# Altium schematic sheet Grace.SchDoc(Grace)
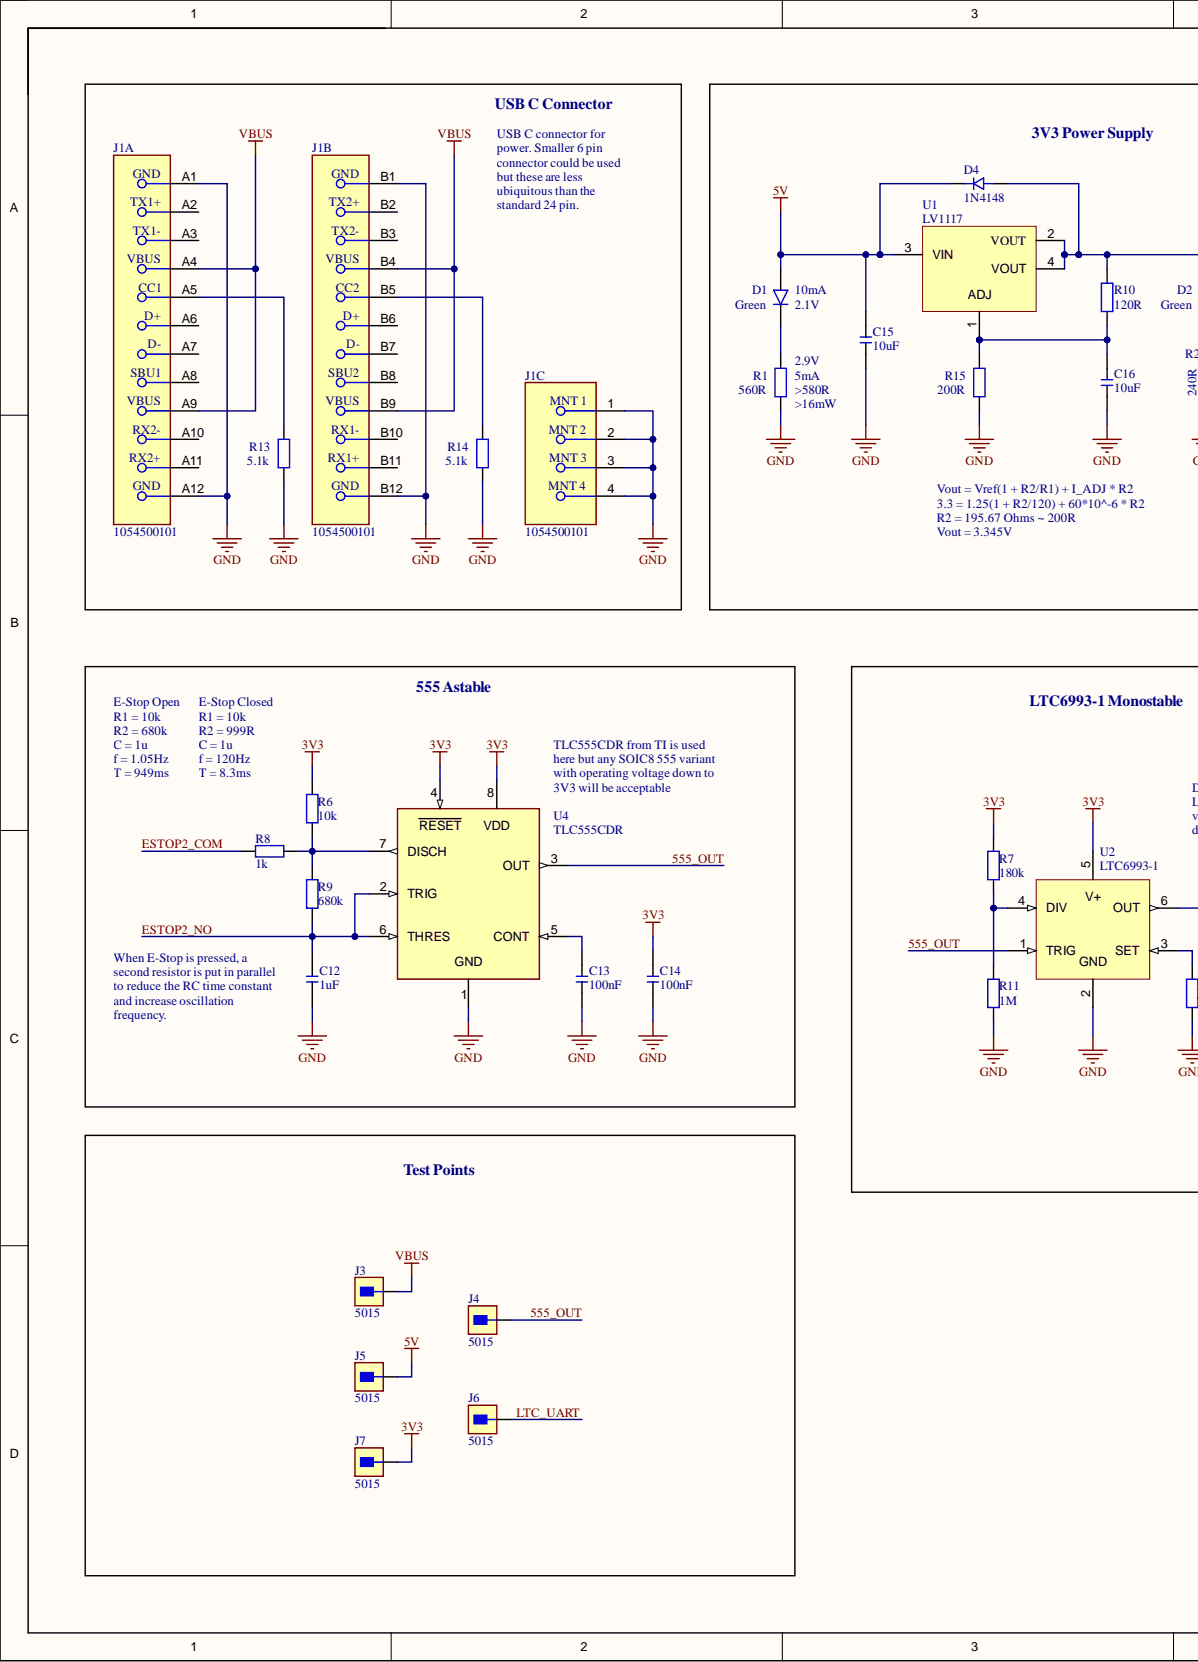
[diagram p0: Grace.SchDoc, left]
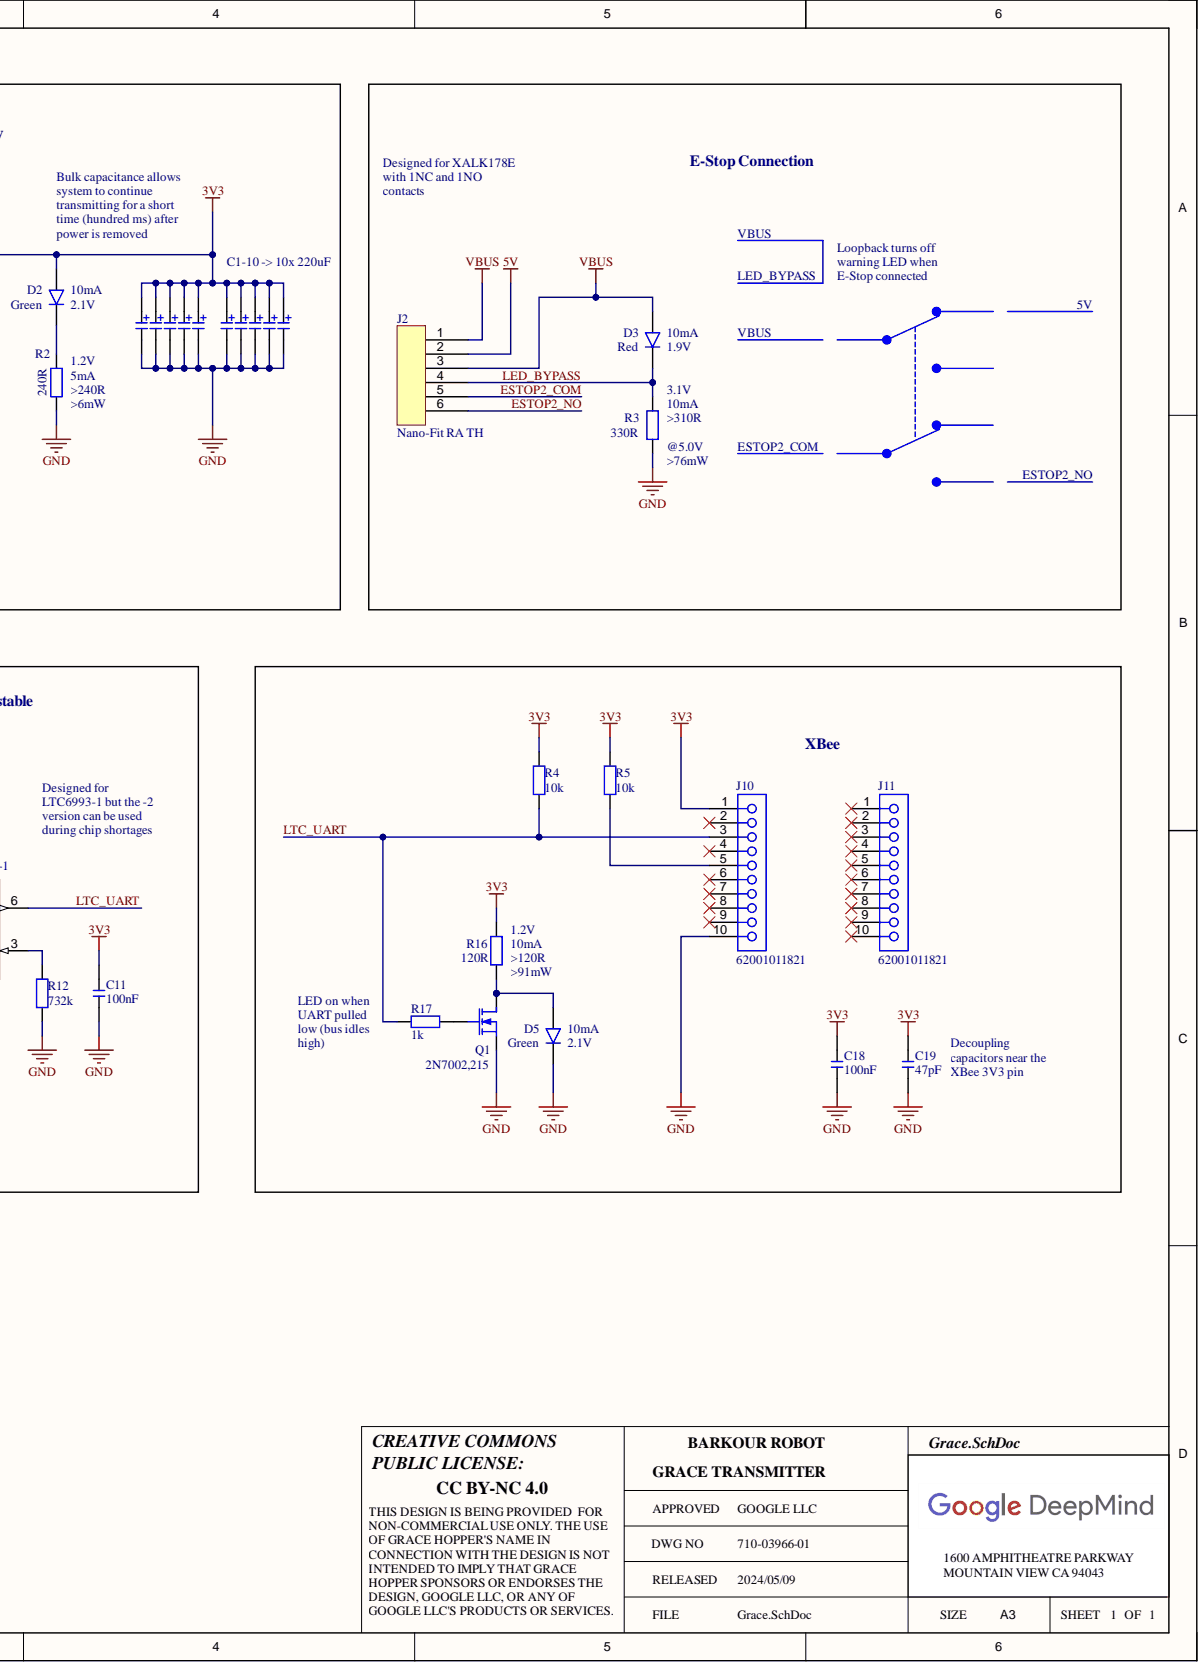
[diagram p1: Grace.SchDoc, right]

## Parts
C1  220uF  [CAP ALSMD 6.6MM H7.7MM]
C2  220uF  [CAP ALSMD 6.6MM H7.7MM]  (value not drawn)
C3  220uF  [CAP ALSMD 6.6MM H7.7MM]  (value not drawn)
C4  220uF  [CAP ALSMD 6.6MM H7.7MM]  (value not drawn)
C5  220uF  [CAP ALSMD 6.6MM H7.7MM]  (value not drawn)
C6  220uF  [CAP ALSMD 6.6MM H7.7MM]  (value not drawn)
C7  220uF  [CAP ALSMD 6.6MM H7.7MM]
C8  220uF  [CAP ALSMD 6.6MM H7.7MM]  (value not drawn)
C9  220uF  [CAP ALSMD 6.6MM H7.7MM]
C10  220uF  [CAP ALSMD 6.6MM H7.7MM]
C11  100nF  [CAP 0603_1608 RF]
C12  1uF  [CAP 0603_1608 RF]
C13  100nF  [CAP 0603_1608 RF]
C14  100nF  [CAP 0603_1608 RF]
C15  10uF  [CAP 1206_3216]
C16  10uF  [CAP 1206_3216]
C18  100nF  [CAP 0603_1608 RF]
C19  47pF  [CAP 0603_1608 RF]
D1  Colour: Green  Forward Current: 10mA  Forward Voltage: 2.1V  [LED TH 5mm]  (hidden comment: HLMP-3507)
D2  Colour: Green  Forward Current: 10mA  Forward Voltage: 2.1V  [LED TH 5mm]  (hidden comment: HLMP-3507)
D3  Colour: Red  Forward Current: 10mA  Forward Voltage: 1.9V  [LED TH 5mm]  (hidden comment: HLMP-3301)
D4  1N4148WS-7-F  [SOD323]  (value not drawn)
D5  Colour: Green  Forward Current: 10mA  Forward Voltage: 2.1V  [LED TH 5mm]  (hidden comment: HLMP-3507)
J1  1054500101  [Molex 1054500101 - With Model]  (parts A,B,C)
J2  Colloquial: Nano-Fit RA TH  [MOLEX 1053131106]  (hidden comment: 105313-1206)
J3  5015  [FP-5015-MFG-RF]
J4  5015  [FP-5015-MFG-RF]
J5  5015  [FP-5015-MFG-RF]
J6  5015  [FP-5015-MFG-RF]
J7  5015  [FP-5015-MFG-RF]
J10  62001011821  [62001011821]
J11  62001011821  [62001011821]
Q1  2N7002,215  [NEXPERIA SOT-23-3]
R1  560R  [RES 0603_1608 RF]
R2  240R  [RES 0603_1608 RF]
R3  330R  [RES 0603_1608 RF]
R4  10k  [RES 0603_1608 RF]
R5  10k  [RES 0603_1608 RF]
R6  10k  [RES 0603_1608 RF]
R7  180k  [RES 0603_1608 RF]
R8  1k  [RES 0603_1608 RF]
R9  680k  [RES 0603_1608 RF]
R10  120R  [RES 1206_3216 RF]
R11  1M  [RES 0603_1608 RF]
R12  732k  [RES 0603_1608 RF]
R13  5.1k  [RES 0603_1608 RF]
R14  5.1k  [RES 0603_1608 RF]
R15  200R  [RES 1206_3216 RF]
R16  120R  [RES 1206_3216 RF]
R17  1k  [RES 0603_1608 RF]
U1  Colloquial: LV1117  [TI SOT-223 DCY]  (hidden comment: TLV1117CDCY)
U2  LTC6993CS6-1#TRMPBF  [LT TSOT-23-6 S6]  (value not drawn)
U4  TLC555CDR  [TI SOIC-8 D0008A]

## Nets
3V3: C1.1 C2.1 C3.1 C4.1 C5.1 C6.1 C7.1 C8.1 C9.1 C10.1 C11.2 C14.2 C18.2 C19.2 D2.2 D4.1 J7.1 J10.1 R4.2 R5.2 R6.2 R7.2 R10.2 R16.2 U1.2(VOUT) U1.4(VOUT) U2.5(V+) U4.4 U4.8
5V: C15.2 D1.2 D4.2 J2.2 J5.1 U1.3(VIN)
GND: C1.2 C2.2 C3.2 C4.2 C5.2 C6.2 C7.2 C8.2 C9.2 C10.2 C11.1 C12.1 C13.1 C14.1 C15.1 C16.1 C18.1 C19.1 D5.1 J1.1 J1.2 J1.3 J1.4 J1.A1 J1.A12 J1.B1 J1.B12 J10.10 Q1.2 R1.1 R2.1 R3.1 R11.1 R12.1 R13.1 R14.1 R15.1 U2.2 U4.1
VBUS: D3.2 J1.A4 J1.A9 J1.B4 J1.B9 J2.1 J2.3 J3.1
555_OUT: J4.1 U2.1(TRIG) U4.3(OUT)
ESTOP2_COM: J2.5 R8.1
ESTOP2_NO: C12.2 J2.6 R9.1 U4.2(TRIG) U4.6(THRES)
LED_BYPASS: D3.1 J2.4 R3.2
LTC_UART: J6.1 J10.3 R4.1 R17.1 U2.6(OUT)
NetC13_2: C13.2 U4.5(CONT)
NetC16_2: C16.2 R10.1 R15.2 U1.1
NetD1_1: D1.1 R1.2
NetD2_1: D2.1 R2.2
NetD5_2: D5.2 Q1.3 R16.1
NetJ1_A5: J1.A5 R13.2
NetJ1_B5: J1.B5 R14.2
NetJ10_5: J10.5 R5.1
NetQ1_1: Q1.1 R17.2
NetR6_1: R6.1 R8.2 R9.2 U4.7(DISCH)
NetR7_1: R7.1 R11.2 U2.4(DIV)
NetR12_2: R12.2 U2.3(SET)

Not connected: J1 A2 A3 A6 A7 A8 A10 A11 B2 B3 B6 B7 B8 B10 B11; J10 2 4 6 7 8 9; J11 1 2 3 4 5 6 7 8 9 10

## Library data of the parts
C1-C10 = C_POL: Polarised Capacitor; Tolerance: 20%; Voltage Rating: 10V; Capacitance: 220uF; Component Kind: Standard; Diameter: 6.3mm; Height: 7.7mm; Library Name: DMR_common_lib.SchLib
C11 C13 C14 C18 = C: Capacitor; Dielectric: X7R; Tolerance: 20%; Voltage Rating: 25V; Capacitance: 100nF; Component Kind: Standard; Size Code: 0603; Library Name: DMR_common_lib.SchLib
C12 = C: Capacitor; Dielectric: X7R; Tolerance: 20%; Voltage Rating: 10V; Capacitance: 1uF; Component Kind: Standard; Size Code: 0603; Library Name: DMR_common_lib.SchLib
C15 C16 = C: Generic Capacitor; Temperature Coefficient: X5R; Tolerance: 10%; Voltage Rating: 25V; Capacitance: 10uF; Component Kind: Standard; Size Code: 1206; Library Name: DMR_common_lib.SchLib
C19 = C: Capacitor; Dielectric: C0G; Tolerance: 20%; Voltage Rating: 50V; Capacitance: 47pF; Component Kind: Standard; Size Code: 0603; Library Name: DMR_common_lib.SchLib
D1 D2 D5 = LED_TH5_GREEN: Green 5mm TH LED, T-1 3/4, 10mA Ic, 2.1V; Luminous Intensity: 4.7mcd; Wavelength: 569nm; Case Size: 5mm; Component Kind: Standard; Manufacturer Part Number: HLMP-3507; Library Name: DMR_common_lib.SchLib
D3 = LED_TH5_RED: Red 5mm TH LED, T-1 3/4, 10mA Ic, 1.9V; Luminous Intensity: 6.1mcd; Wavelength: 626nm; Case Size: 5mm; Component Kind: Standard; Manufacturer Part Number: HLMP-3301; Library Name: DMR_common_lib.SchLib
D4 = 1N4148: Signal Diode VR=75V IF=150mA Trr=4ns P=200mW; Manufacturer: Diodes; Average Rectified Current: 150mA; Capacitance: 2pF; Element Configuration: Single; Forward Current: 300mA; Forward Voltage: 1.25V; Max Forward Surge Current (Ifsm): 2A; Max Repetitive Reverse Voltage (Vrrm): 75V; Max Reverse Current: 1uA; Polarity: Standard; Power Dissipation: 200mW; Recovery Time: 4ns; Category: Rectifier Diodes; Colloquial: 1N4148; Component Kind: Standard; Contact Plating: Tin,Matte; Current Rating: 150mA; Height: 1mm; Lead Free: Lead Free; Length: 1.8mm; Manufacturer Part Number: 1N4148WS-7-F; Max Operating Temperature: 150°C; Max Reverse Voltage (DC): 75V; Max Surge Current: 2A; Min Operating Temperature: -65°C; Mount: Surface Mount; Number of Pins: 2; Output Current: 150mA; Package Quantity: 3000; Packaging: Tape and Reel; Peak Non-Repetitive Surge Current: 2A; Power Rating: 200mW; Pricing 1: 3000=0.03600, 6000=0.03200, 12000=0.02900, 30000=0.02700, 60000=0.02300 (USD); Radiation Hardening: No; REACH SVHC: No SVHC; Reverse Recovery Time: 4ns; Reverse Recovery Time-Max: 4ns; RoHS: Yes; RoHS Compliant: Yes; Series: -; Stock 1: 18000; Supplier 1: RSComponents; Supplier Part Number 1: 9228070; Voltage: 75V; Voltage Rating (DC): 75V; Weight: 0.000173oz; Width: 1.4mm; Library Name: DMR_common_lib.SchLib
J1 = 1054500101: Conn USB 3.1 Type C F 24 POS Solder RA SMD 24 Terminal 1 Port USB 3.1 T/R; Manufacturer: Molex; Conn Orientation: Right Angle; Current Rating: 0.25/1.25/5A; Is Female: yes; Number Of Contacts: 24; Pitch: 0.5mm; Shielding: true; Usb Standard: USB 3.1; Voltage Rating: 30V; Automotive: No; Category: Conn; Component Kind: Standard; ComponentLink2Description: Datasheet; ComponentLink2URL: https://www.molex.com/webdocs/datasheets/pdf/en-us/1054500101_IO_CONNECTORS.pdf; Contact Material: Gold,Nickel; Device Class L1: Connectors; Device Class L2: USB Connectors; Device Class L3: unset; Digikey Description: CONN RCP USB3.1 TYPEC 24P SMD RA; Footprint Url: https://www.molex.com/pdm_docs/sd/1054500101_sd.pdf; Height: 3.44mm; Lead Free: Yes; Manufacturer Part Number: 1054500101; Mouser Description: USB Shielded I/O Receptacle; Type C; Right Angle; Surface Mount; Gold over Nickel; Octopart: https://octopart.com/105450-0101-molex-68082325; Package: 0; RoHS: TRUE; Temperature: -30°C to +85°C; Temperature Range High: +85°C; Temperature Range Low: -30°C; Library Name: DMR_common_lib.SchLib
J2 = Nano-Fit RA TH 6 Way Header: Nano-Fit Right Angle Header; Through Hole; 2.50mm; Single Row; 6 Circuit; Gold Plated; Manufacturer: Molex; Gender: Male; Category: Headers and Wire Housings; Color: Black; Component Kind: Standard; Contact Material: Brass; Contact Plating: Gold; Manufacturer Part Number: 105313-1206; Mount: Through Hole; Number of Rows: 1; Pricing 1: 10=1.38000 (GBP); REACH SVHC: Unknown; RoHS: Yes; RoHS Compliant: Yes; Stock 1: 621; Supplier 1: RSComponents; Supplier Part Number 1: 1225087; Termination: Through Hole; Library Name: DMR_common_lib.SchLib
J3-J7 = 5015: PC TEST POINT MINIATURE; Manufacturer: keystone; Conn Orientation: Straight; Number Of Contacts: 1; Automotive: No; Category: Conn; Component Kind: Standard; ComponentLink2Description: Datasheet; ComponentLink2URL: http://www.keyelco.com/product-pdf.cfm?p=1353; Contact Material: Silver; Device Class L1: Connectors; Device Class L2: unset; Device Class L3: unset; Digikey Description: PC TEST POINT MINIATURE; Height: 1.65mm; Lead Free: Yes; Manufacturer Part Number: 5015; Octopart: https://octopart.com/5015-keystone-3822; Package: 5015; RoHS: TRUE; Library Name: DMR_common_lib.SchLib
J10 J11 = CMP-1502-01210-2: NexarPartId: 65162168; Contact Resistance: 20mΩ; Current Rating: 2A; Gender: Female; Operating Supply Voltage: 250V; Component Kind: Standard; Lead Pitch: 2mm; Library Name: Altium Content Vault; Number of Pins: 10; Orientation: Vertical; RoHS: Non-Compliant
Q1 = 2N7002: MOSFET N-CH 60V 300MA SOT-23; Manufacturer: Nexperia; Category: MOSFETs; Component Kind: Standard; ComponentLink1Description: http://www.farnell.com/datasheets/1499179.pdf?src-supplier=Farnell; ComponentLink1URL: http://www.farnell.com/datasheets/1499179.pdf?src-supplier=Farnell; ComponentLink2Description: https://assets.nexperia.com/documents/data-sheet/BSS138PS.pdf?src-supplier=Digi-Key; ComponentLink2URL: https://assets.nexperia.com/documents/data-sheet/BSS138PS.pdf?src-supplier=Digi-Key; ComponentLink3Description: https://assets.nexperia.com/documents/data-sheet/2N7002.pdf?src-supplier=Digi-Key; ComponentLink3URL: https://assets.nexperia.com/documents/data-sheet/2N7002.pdf?src-supplier=Digi-Key; ComponentLink4Description: http://www.farnell.com/datasheets/1499179.pdf?src-supplier=Newark; ComponentLink4URL: http://www.farnell.com/datasheets/1499179.pdf?src-supplier=Newark; Manufacturer Part Number: 2N7002,215; Pricing 1: 3000=0.05700, 9000=0.05640, 24000=0.05590, 45000=0.05530 (USD); RoHS: Yes; RoHS Compliant: Yes; Stock 1: 243000; Supplier 1: Arrow Electronics; Supplier Part Number 1: 2N7002,215; Library Name: DMR_common_lib.SchLib
R1-R9 R11-R14 R17 = R: Resistor; Power Rating: 100mW; Temperature Coefficient: 100ppm/°C; Tolerance: 1%; Voltage Rating: 75V; Component Kind: Standard; Size Code: 0603; Library Name: DMR_common_lib.SchLib
  R1: Resistance: 560R
  R2: Resistance: 240R
  R3: Resistance: 330R
  R4-R6: Resistance: 10k
  R7: Resistance: 180k
  R8 R17: Resistance: 1k
  R9: Resistance: 680k
  R11: Resistance: 1M
  R12: Resistance: 732k
  R13 R14: Resistance: 5.1k
R10 R15 R16 = R: Resistor; Power Rating: 250mW; Temperature Coefficient: 100ppm/°C; Tolerance: 1%; Voltage Rating: 200V; Component Kind: Standard; Size Code: 1206; Library Name: DMR_common_lib.SchLib
  R10 R16: Resistance: 120R
  R15: Resistance: 200R
U1 = TLV1117CDCY: LV1117 type LDO Regulator Pos 1.25V to 13.7V 0.8A 4-Pin(3+Tab) SOT-223 Tube; Manufacturer: Texas Instruments; Dropout Voltage: 1.2V; Input Bias Current: 5mA; Max Input Voltage: 15V; Max Output Current: 800mA; Min Input Voltage: 2.7V; Min Output Voltage: 1.238V; Number of Outputs: 1; Output Type: Adjustable; Output Voltage: 13.75V; Polarity: Positive; Reference Voltage: 1.262V; Case/Package: SOT-223; Category: Voltage Regulators - Linear; Component Kind: Standard; ComponentLink1Description: https://datasheet.ciiva.com/27050/825601-27050234.pdf?src-supplier=Jameco; ComponentLink1URL: https://datasheet.ciiva.com/27050/825601-27050234.pdf?src-supplier=Jameco; ComponentLink2Description: https://datasheet.ciiva.com/15111/getdatasheetpartid-67440-15111324.pdf?src-supplier=Verical; ComponentLink2URL: https://datasheet.ciiva.com/15111/getdatasheetpartid-67440-15111324.pdf?src-supplier=Verical; ComponentLink3Description: https://datasheet.ciiva.com/2062/tlv1117-2062013.pdf?src-supplier=Digi-Key; ComponentLink3URL: https://datasheet.ciiva.com/2062/tlv1117-2062013.pdf?src-supplier=Digi-Key; ComponentLink4Description: https://datasheet.ciiva.com/14275/tlv1117-15-14275165.pdf?src-supplier=Avnet; ComponentLink4URL: https://datasheet.ciiva.com/14275/tlv1117-15-14275165.pdf?src-supplier=Avnet; Height: 1.6mm; Lead Free: Lead Free; Length: 6.5mm; Manufacturer Part Number: TLV1117CDCY; Max Operating Temperature: 125°C; Max Output Voltage: 13.7V; Min Current Limit: 800mA; Min Operating Temperature: -40°C; Mount: Surface Mount; Number of Pins: 4; Number of Regulators: 1; Output Current: 800mA; Package Quantity: 80; Packaging: Rail/Tube; Pricing 1: 1=0.89000, 10=0.79400, 25=0.75400, 100=0.61930, 250=0.57888, 500=0.51156, 1000=0.40640 (USD); Quiescent Current: 5mA; Radiation Hardening: No; REACH SVHC: No SVHC; RoHS: Yes; RoHS Compliant: Yes; Series: -; Stock 1: 1854; Supplier 1: Digi-Key; Supplier Part Number 1: 296-26779-5-ND; Width: 3.5mm; Library Name: DMR_common_lib.SchLib
U2 = LTC6993-1: TimerBlox: Monostable Pulse Generator (One Shot), 2.25 to 5.5 V, 0 to 70 degC, 6-Pin TSOT-23 (S6), RoHS, Tape and Reel; Manufacturer: Linear Technology; RSET: 800 KOhm Max.; tWIDTH: 5 ns Typ.; VSET: 0.97 to 1.03 V; Colloquial: LTC6993-1; Component Kind: Standard; ComponentLink1Description: Manufacturer URL; ComponentLink1URL: http://www.linear.com/; ComponentLink2Description: Datasheet; ComponentLink2URL: http://cds.linear.com/docs/en/datasheet/69931234fb.pdf; ComponentLink3Description: Package Specification; ComponentLink3URL: http://cds.linear.com/docs/en/packaging/SOT_6_05-08-1636.pdf; DatasheetVersion: Rev. B; Mounting Technology: Surface Mount; PackageDescription: 6-Pin Small Out-Line Transistor, Body 2.9 x 1.625 mm, Pitch 0.95 mm; PackageVersion: Jun-10; Packing: Tape and Reel; PartNumber: LTC6993CS6-1#TRMPBF; RoHS: TRUE; Temperature Range: 0 to 70 degC; V+: 2.25 to 5.5 V; Library Name: DMR_common_lib.SchLib
U4 = TLC555CDR: 2.1-MHz, 250-µA, Low-Power Timer 8-SOIC 0 to 70; Current Rating: 250A; Frequency: 2.1MHz; High Level Output Current: -10mA; Low Level Output Current: 100mA; Max Power Dissipation: 725mW; Max Supply Voltage: 15V; Min Supply Voltage: 2V; Number of Channels: 1; Operating Supply Current: 360uA; Quiescent Current: 250uA; Case/Package: SOIC; Component Kind: Standard; Height: 1.58mm; Lead Free: Lead Free; Length: 4.9mm; Max Operating Temperature: 125°C; Min Operating Temperature: -40°C; Mount: Surface Mount; Number of Pins: 8; Number of Timers/Counters: 1; Package Quantity: 2500; Packaging: Tape and Reel; Power Dissipation: 725mW; Radiation Hardening: No; REACH SVHC: No SVHC; Supplier 1: Farnell; Supplier Part Number 1: 3121208; Termination: SMD/SMT; Weight: 0.002561oz; Width: 3.91mm; Library Name: DMR_common_lib.SchLib
